# Altium schematic sheet A_Flotador.SchDoc(A_Flotador)
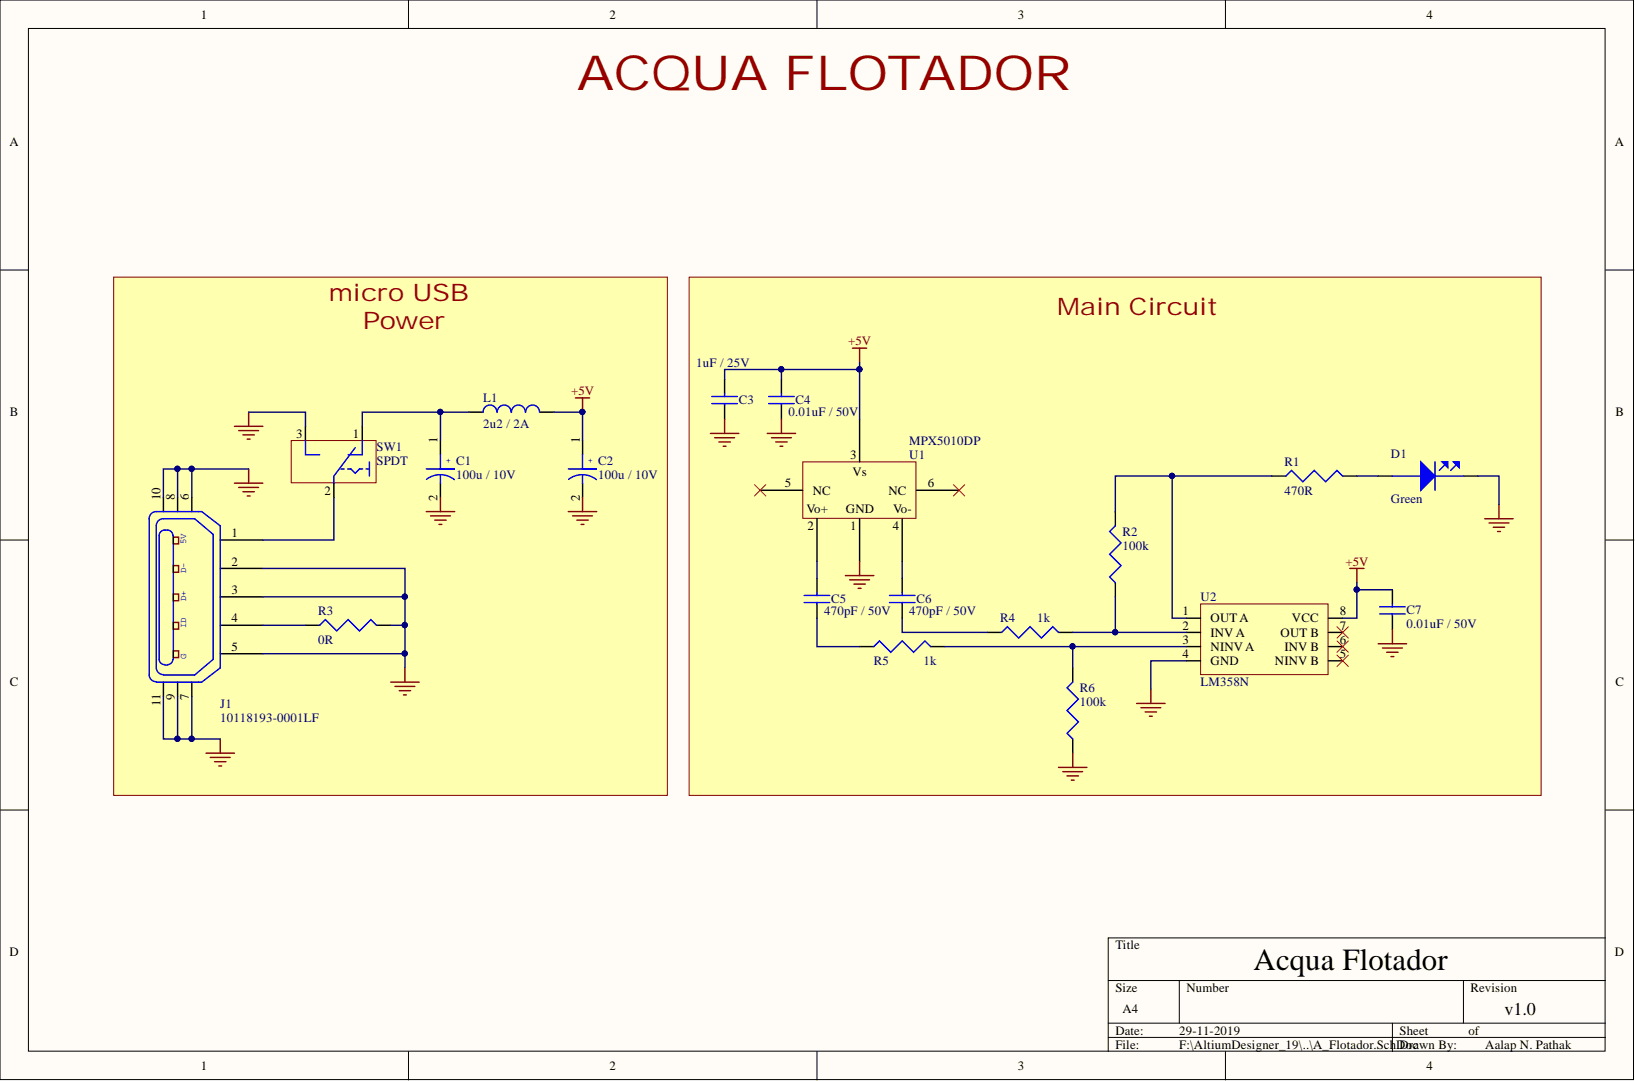
[diagram p0: A_Flotador.SchDoc]

## Parts
C1  100u / 10V  [C_ALU_C_SIZE]
C2  100u / 10V  [C_ALU_C_SIZE]
C3  1uF / 25V  Voltage Rating (DC): 25V  Capacitance: 1uF  [C0805]
C4  0.01uF / 50V  [C0805]
C5  470pF / 50V  Capacitance: 470pF  [C0805]
C6  470pF / 50V  Voltage Rating (DC): 50V  Capacitance: 470pF  [C0805]
C7  0.01uF / 50V  [C0805]
D1  Green  [LED_0603]
J1  10118193-0001LF  [MicroUSB]
L1  2u2 / 2A  Max DC Current: 2A  [C1210_IND]
R1  470R  [R0805]
R2  100k  [R0805]
R3  0R  [R0805]
R4  1k  [R0805]
R5  1k  [R0805]
R6  100k  [R0805]
SW1  SPDT  [SPDT]
U1  MPX5010DP  [MPX5010DP]
U2  LM358N  [LM358N]

## Nets
+5V: C2.1 C3.2 C4.2 C7.2 L1.2 U1.3(Vs) U2.8(VCC)
GND: C1.2 C2.2 C3.1 C4.1 C7.1 D1.1 J1.2 J1.3 J1.5 J1.6 J1.7 J1.8 J1.9 J1.10 J1.11 R3.2 R6.1 SW1.3 U1.1 U2.4(GND)
NetC1_1: C1.1 L1.1 SW1.1
NetC5_1: C5.1 R5.1
NetC5_2: C5.2 U1.2
NetC6_1: C6.1 R4.1
NetC6_2: C6.2 U1.4
NetD1_2: D1.2 R1.2
NetJ1_1: J1.1 SW1.2
NetJ1_4: J1.4 R3.1
NetR1_1: R1.1 R2.2 U2.1(OUT_A)
NetR2_1: R2.1 R4.2 U2.2(INV_A)
NetR5_2: R5.2 R6.2 U2.3(NINV_A)

Not connected: U1 5(NC) 6(NC); U2 5(NINV_B) 6(INV_B) 7(OUT_B)

## Library data of the parts
C1 C2 = EEE-1AA101WR: Cap Aluminum 100uF 10V 20% (5 X 5.4mm) SMD 50mA 1000 hr 85°C T/R; Manufacturer: Panasonic; Life (Hours): 1000Hour; Voltage Rating (DC): 10V; Voltage Rating: 10V; Tolerance: 20%; Termination: SMD/SMT; Supplier Part Number 1: PCE3867CT-ND; Supplier 1: Digi-Key; Stock 1: 121782; RoHS: Yes; Ripple Current (AC): 50mA; Ripple Current: 50mA; REACH SVHC: Unknown; Radiation Hardening: No; Pricing 1: 1 = 0.32000, 10 = 0.22100, 100 = 0.13280, 500 = 0.09964 (USD); Packaging: Tape and Reel; Package Quantity: 1; Mount: Surface Mount,PCB; Min Operating Temperature: -40°C; Max Operating Temperature: 85°C; Manufacturer Part Number: EEE-1AA101WR; Length: 5.5mm; Lead Free: Lead Free; Height - Seated (Max): 0.213inch; Height: 5.4mm; Diameter: 5mm; Contact Plating: Tin; ComponentLink8URL: https://datasheet.ciiva.com/11673/aba0000ce2-11673882.pdf?src-supplier=Avnet; ComponentLink8Description: https://datasheet.ciiva.com/11673/aba0000ce2-11673882.pdf?src-supplier=Avnet; ComponentLink7URL: https://datasheet.ciiva.com/14605/70255710-14605689.pdf?src-supplier=Allied+Electronics; ComponentLink7Description: https://datasheet.ciiva.com/14605/70255710-14605689.pdf?src-supplier=Allied+Electronics; ComponentLink6URL: https://datasheet.ciiva.com/14598/70255710-14598233.pdf?src-supplier=Allied+Electronics; ComponentLink6Description: https://datasheet.ciiva.com/14598/70255710-14598233.pdf?src-supplier=Allied+Electronics; ComponentLink5URL: https://datasheet.ciiva.com/16427/aba0000ce2-16427544.pdf?src-supplier=Future+Electronics; ComponentLink5Description: https://datasheet.ciiva.com/16427/aba0000ce2-16427544.pdf?src-supplier=Future+Electronics; ComponentLink4URL: https://datasheet.ciiva.com/30075/11aba0000ce2-30075303.pdf?src-supplier=Onlinecomponents.com; ComponentLink4Description: https://datasheet.ciiva.com/30075/11aba0000ce2-30075303.pdf?src-supplier=Onlinecomponents.com; ComponentLink3URL: https://datasheet.ciiva.com/24027/aba0000ce2-40801-24027541.pdf?src-supplier=Mouser; ComponentLink3Description: https://datasheet.ciiva.com/24027/aba0000ce2-40801-24027541.pdf?src-supplier=Mouser; ComponentLink2URL: https://datasheet.ciiva.com/2983/aba0000ce2-2983906.pdf?src-supplier=Avnet; ComponentLink2Description: https://datasheet.ciiva.com/2983/aba0000ce2-2983906.pdf?src-supplier=Avnet; ComponentLink1URL: https://datasheet.ciiva.com/26945/getdatasheetpartid-169213-26945071.pdf?src-supplier=Verical; ComponentLink1Description: https://datasheet.ciiva.com/26945/getdatasheetpartid-169213-26945071.pdf?src-supplier=Verical; Component Kind: Standard; Case/Package: Radial; Capacitance: 100uF; Library Name: AFlotador_SchLib.SchLib
C3 = C2012X5R1E105K125AA: CAP CER 1UF 25V X5R 0805; Manufacturer: TDK; Tolerance: 10%; Dielectric Material: Ceramic; Width: 0.049inch; Weight: 0.000194oz; Voltage Rating: 25V; Voltage: 25V; Thickness: 0.057inch; Termination: SMD/SMT; Supplier Part Number 1: 445-1419-1-ND; Supplier 1: Digi-Key; Stock 1: 57325; RoHS: Yes; REACH SVHC: No SVHC; Radiation Hardening: No; Pricing 1: 1 = 0.27000, 10 = 0.18200, 100 = 0.09230, 500 = 0.06314, 1000 = 0.05586 (USD); Packaging: Tape and Reel; Package Quantity: 2000; Number of Pins: 2; Mount: Surface Mount; Min Operating Temperature: -55°C; Max Operating Temperature: 85°C; Manufacturer Part Number: C2012X5R1E105K125AA; Length: 2mm; Lead Free: Lead Free; Height: 1.25mm; Dielectric: X5R; Depth: 1.25mm; Composition: Ceramic; ComponentLink4URL: https://datasheet.ciiva.com/15192/getdatasheetpartid-726707-15192140.pdf?src-supplier=Verical; ComponentLink4Description: https://datasheet.ciiva.com/15192/getdatasheetpartid-726707-15192140.pdf?src-supplier=Verical; ComponentLink3URL: https://datasheet.ciiva.com/28602/1744655-28602171.pdf?src-supplier=Element14; ComponentLink3Description: https://datasheet.ciiva.com/28602/1744655-28602171.pdf?src-supplier=Element14; ComponentLink2URL: https://datasheet.ciiva.com/16449/e412-c-16449595.pdf?src-supplier=Future+Electronics; ComponentLink2Description: https://datasheet.ciiva.com/16449/e412-c-16449595.pdf?src-supplier=Future+Electronics; ComponentLink1URL: https://datasheet.ciiva.com/11787/0900766b80c1bb00-11787608.pdf?src-supplier=RSComponents; ComponentLink1Description: https://datasheet.ciiva.com/11787/0900766b80c1bb00-11787608.pdf?src-supplier=RSComponents; Component Kind: Standard; Case Code (Metric): 2012; Case Code (Imperial): 0805; Case/Package: 0805; Library Name: AFlotador_SchLib.SchLib
C4 C7 = C0805C103K5RACTU: CAP CER 0.01UF 50V X7R 0805; Manufacturer: KEMET; Tolerance: 10%; Capacitance: 10nF; Width: 1.2mm; Voltage Rating (DC): 50V; Voltage Rating: 50V; Voltage: 50V; Termination: SMD/SMT; Supplier Part Number 1: 399-1158-1-ND; Supplier 1: Digi-Key; Stock 1: 3202760; RoHS: Yes; Radiation Hardening: No; Pricing 1: 1 = 0.15000, 10 = 0.10500, 100 = 0.04950, 500 = 0.03474, 1000 = 0.02876 (USD); Packaging: Tape and Reel; Package Quantity: 4000; Mount: Surface Mount; Min Operating Temperature: -55°C; Max Operating Temperature: 125°C; Manufacturer Part Number: C0805C103K5RACTU; Length: 2mm; Lead Pitch: 0.75mm; Height: 0.78mm; Dielectric: X7R; Depth: 1.25mm; Contact Plating: Tin,Matte; ComponentLink7URL: https://api.kemet.com/component-edge/download/datasheet/C0805C103K5RACTU.pdf?src-supplier=Digi-Key; ComponentLink7Description: https://api.kemet.com/component-edge/download/datasheet/C0805C103K5RACTU.pdf?src-supplier=Digi-Key; ComponentLink6URL: http://www.farnell.com/datasheets/2121238.pdf?src-supplier=Element14; ComponentLink6Description: http://www.farnell.com/datasheets/2121238.pdf?src-supplier=Element14; ComponentLink5URL: http://www.kemet.com/Lists/ProductCatalog/Attachments/53/KEM_C1002_X7R_SMD.pdf?src-supplier=Avnet; ComponentLink5Description: http://www.kemet.com/Lists/ProductCatalog/Attachments/53/KEM_C1002_X7R_SMD.pdf?src-supplier=Avnet; ComponentLink4URL: http://www.farnell.com/datasheets/2315425.pdf?src-supplier=Farnell; ComponentLink4Description: http://www.farnell.com/datasheets/2315425.pdf?src-supplier=Farnell; ComponentLink3URL: https://www.verical.com/server-webapp/html/getDatasheet?partID=293414&src-supplier=Verical; ComponentLink3Description: https://www.verical.com/server-webapp/html/getDatasheet?partID=293414&src-supplier=Verical; ComponentLink2URL: http://components.arrow.com/part/dataview/0323_00011329?src-supplier=Arrow+Europe; ComponentLink2Description: http://components.arrow.com/part/dataview/0323_00011329?src-supplier=Arrow+Europe; ComponentLink1URL: http://www.onlinecomponents.com/productFiles/mf-KEM/kem_c1002_x7r_smd.pdf?src-supplier=Onlinecomponents.com; ComponentLink1Description: http://www.onlinecomponents.com/productFiles/mf-KEM/kem_c1002_x7r_smd.pdf?src-supplier=Onlinecomponents.com; Component Kind: Standard; Case Code (Metric): 2012; Case Code (Imperial): 0805; Case/Package: 0805; Library Name: AFlotador_SchLib.SchLib
C5 C6 = C0805C471K5RACTU: CAP CER 470PF 50V X7R 0805; Manufacturer: KEMET; Tolerance: 10%; Dissipation Factor: 2.5%; Dielectric Material: Ceramic; Width: 1.2mm; Voltage Rating: 50V; Voltage: 50V; Thickness: 0.035inch; Termination: SMD/SMT; Supplier Part Number 1: 399-1142-1-ND; Supplier 1: Digi-Key; Stock 1: 229830; RoHS: Yes; REACH SVHC: No SVHC; Radiation Hardening: No; Pricing 1: 1 = 0.12000, 10 = 0.09000, 100 = 0.04030, 500 = 0.02876, 1000 = 0.02260 (USD); Packaging: Tape and Reel; Package Quantity: 1; Number of Pins: 2; Mount: Surface Mount; Min Operating Temperature: -55°C; Max Operating Temperature: 125°C; Manufacturer Part Number: C0805C471K5RACTU; Length: 2mm; Lead Pitch: 0.75mm; Height: 0.78mm; Dielectric: X7R; Depth: 1.25mm; ComponentLink4URL: https://datasheet.ciiva.com/28614/kem-c1002-x7r-smd-28614760.pdf?src-supplier=Element14; ComponentLink4Description: https://datasheet.ciiva.com/28614/kem-c1002-x7r-smd-28614760.pdf?src-supplier=Element14; ComponentLink3URL: https://datasheet.ciiva.com/46446/1851736-46446251.pdf?src-supplier=Element14; ComponentLink3Description: https://datasheet.ciiva.com/46446/1851736-46446251.pdf?src-supplier=Element14; ComponentLink2URL: https://datasheet.ciiva.com/18193/getdatasheetpartid-344591-18193834.pdf?src-supplier=Verical; ComponentLink2Description: https://datasheet.ciiva.com/18193/getdatasheetpartid-344591-18193834.pdf?src-supplier=Verical; ComponentLink1URL: https://datasheet.ciiva.com/16624/datasheetpn-c0805c471k5ractu-16624899.pdf?src-supplier=Avnet+Europe; ComponentLink1Description: https://datasheet.ciiva.com/16624/datasheetpn-c0805c471k5ractu-16624899.pdf?src-supplier=Avnet+Europe; Component Kind: Standard; Case Code (Metric): 2012; Case Code (Imperial): 0805; Case/Package: 0805; Library Name: AFlotador_SchLib.SchLib
  C5: Voltage Rating (DC): 50V
D1 = LGL29K-G2J1-24-Z: LED Uni-Color Green 572nm 2-Pin Chip 0603(1608Metric) T/R; Manufacturer: Osram Opto; Viewing Angle: 160°; Test Current: 2mA; Reverse Voltage: 12V; Number of LEDs: 1; Luminous Intensity: 5.6mcd; Luminous Flux: 0.012lm; Lens Transparency: Diffused; Lens Style: Flat,Diffused,Colorless,Rectangular; Lens Size: 0.8mm; Lens Color: White; Forward Voltage: 2.2V; Forward Current: 20mA; Dominant Wavelength: 570nm; Width: 0.8mm; Supplier Part Number 1: 475-2709-1-ND; Supplier 1: Digi-Key; Stock 1: 129040; RoHS: Yes; Radiation Hardening: No; Pricing 1: 1 = 0.50000, 10 = 0.36300, 100 = 0.23730, 500 = 0.17054, 1000 = 0.13347, 2500 = 0.12235 (USD); Packaging: Tape and Reel; Package Quantity: 5000; Number of Pins: 2; Number of Elements: 1; Mount: Surface Mount; Min Operating Temperature: -40°C; Max Operating Temperature: 100°C; Manufacturer Part Number: LGL29K-G2J1-24-Z; Length: 1.3mm; Illumination Color: Green; Height: 0.65mm; Depth: 0.8mm; ComponentLink5URL: https://datasheet.ciiva.com/2112/00077107-0-2112886.pdf?src-supplier=Digi-Key; ComponentLink5Description: https://datasheet.ciiva.com/2112/00077107-0-2112886.pdf?src-supplier=Digi-Key; ComponentLink4URL: https://datasheet.ciiva.com/2112/00077107-0-2112888.pdf?src-supplier=Digi-Key; ComponentLink4Description: https://datasheet.ciiva.com/2112/00077107-0-2112888.pdf?src-supplier=Digi-Key; ComponentLink3URL: https://datasheet.ciiva.com/2112/00077107-0-2112887.pdf?src-supplier=Digi-Key; ComponentLink3Description: https://datasheet.ciiva.com/2112/00077107-0-2112887.pdf?src-supplier=Digi-Key; ComponentLink2URL: https://datasheet.ciiva.com/15198/getdatasheetpartid-571127-15198411.pdf?src-supplier=Verical; ComponentLink2Description: https://datasheet.ciiva.com/15198/getdatasheetpartid-571127-15198411.pdf?src-supplier=Verical; ComponentLink1URL: https://datasheet.ciiva.com/4689/g-l29k-39844-4689584.pdf?src-supplier=Mouser; ComponentLink1Description: https://datasheet.ciiva.com/4689/g-l29k-39844-4689584.pdf?src-supplier=Mouser; Component Kind: Standard; Color: Green; Case Code (Metric): 1608; Case Code (Imperial): 0603; Case/Package: 0603; Library Name: AFlotador_SchLib.SchLib
J1 = 10118193-0001LF: CONN RCPT USB2.0 MICRO B SMD R/A; Manufacturer: Amphenol FCI; Voltage Rating: 100V; Pitch: 0.65mm; Number of Ports: 1; Number of Contacts: 5; Insulation Resistance: 1GR; Gender: Female; Current Rating: 1.8A; Connector Type: USB,Receptacle; Termination: Solder; Supplier Part Number 1: 609-4616-1-ND; Supplier 1: Digi-Key; Stock 1: 150983; RoHS: Yes; Radiation Hardening: No; Pricing 1: 1 = 0.43000, 10 = 0.40500, 25 = 0.36440, 50 = 0.32400, 100 = 0.31040, 250 = 0.28344, 500 = 0.26994 (USD); Packaging: Tape and Reel; Package Quantity: 1200; Orientation: Right Angle; Number of Terminals: 5; Mount: Surface Mount,Horizontal; Min Operating Temperature: -55°C; Max Voltage Rating (AC): 100V; Max Operating Temperature: 85°C; Manufacturer Part Number: 10118193-0001LF; Length: 7.86mm; Housing Material: Polymer; Flammability Rating: UL94 V-0; Features: Shielded; Depth: 5.67mm; Contact Plating: Gold; Contact Material: Copper Alloy; ComponentLink7URL: https://datasheet.ciiva.com/14618/70236827-14618887.pdf?src-supplier=Allied+Electronics; ComponentLink7Description: https://datasheet.ciiva.com/14618/70236827-14618887.pdf?src-supplier=Allied+Electronics; ComponentLink6URL: https://datasheet.ciiva.com/851/10118193-851108.pdf?src-supplier=Digi-Key; ComponentLink6Description: https://datasheet.ciiva.com/851/10118193-851108.pdf?src-supplier=Digi-Key; ComponentLink5URL: https://datasheet.ciiva.com/851/10118193-851107.pdf?src-supplier=Digi-Key; ComponentLink5Description: https://datasheet.ciiva.com/851/10118193-851107.pdf?src-supplier=Digi-Key; ComponentLink4URL: https://datasheet.ciiva.com/4598/10118193ac-197151-4598799.pdf?src-supplier=Mouser; ComponentLink4Description: https://datasheet.ciiva.com/4598/10118193ac-197151-4598799.pdf?src-supplier=Mouser; ComponentLink3URL: https://datasheet.ciiva.com/26853/getdatasheetpartid-418618-26853893.pdf?src-supplier=Verical; ComponentLink3Description: https://datasheet.ciiva.com/26853/getdatasheetpartid-418618-26853893.pdf?src-supplier=Verical; ComponentLink2URL: https://datasheet.ciiva.com/14802/10118193-0001lf-webpage-14802386.pdf?src-supplier=Arrow; ComponentLink2Description: https://datasheet.ciiva.com/14802/10118193-0001lf-webpage-14802386.pdf?src-supplier=Arrow; ComponentLink1URL: https://datasheet.ciiva.com/851/10118193-851106.pdf?src-supplier=Digi-Key; ComponentLink1Description: https://datasheet.ciiva.com/851/10118193-851106.pdf?src-supplier=Digi-Key; Component Kind: Standard; Color: Black; Library Name: AFlotador_SchLib.SchLib
L1 = L1210R2R2MDWIT: FIXED IND 2.2UH 2A 80 MOHM SMD; Manufacturer: KEMET; Tolerance: 20%; Test Frequency: 100kHz; Shielding: Unshielded; Self Resonant Frequency: 190MHz; DC Resistance (DCR): 80mR; DC Current: 1.13A; Core Material: Ferrite; Width: 0.098inch; Termination: SMD/SMT; Supplier Part Number 1: 399-9598-1-ND; Supplier 1: Digi-Key; Stock 1: 14739; RoHS: Yes; REACH SVHC: No SVHC; Radiation Hardening: No; Pricing 1: 1 = 0.55000, 10 = 0.47900, 100 = 0.34210, 500 = 0.28738 (USD); Packaging: Tape and Reel; Package Quantity: 1000; Mount: Surface Mount; Min Operating Temperature: -40°C; Military Standard: Not; Max Operating Temperature: 105°C; Manufacturer Part Number: L1210R2R2MDWIT; Length: 0.126inch; Inductance Tolerance: 20%; Inductance: 2.2uH; Height - Seated (Max): 0.106inch; Height: 2.5mm; Depth: 2.7mm; Current Rating: 2A; Composition: Wirewound,Ceramic; ComponentLink5URL: https://datasheet.ciiva.com/8512/f3115-8512543.pdf?src-supplier=Avnet; ComponentLink5Description: https://datasheet.ciiva.com/8512/f3115-8512543.pdf?src-supplier=Avnet; ComponentLink4URL: https://datasheet.ciiva.com/6691/f3115-6691487.pdf?src-supplier=Digi-Key; ComponentLink4Description: https://datasheet.ciiva.com/6691/f3115-6691487.pdf?src-supplier=Digi-Key; ComponentLink3URL: https://datasheet.ciiva.com/4957/f3115-4957838.pdf?src-supplier=Mouser; ComponentLink3Description: https://datasheet.ciiva.com/4957/f3115-4957838.pdf?src-supplier=Mouser; ComponentLink2URL: https://datasheet.ciiva.com/22685/27262-22685038.pdf?src-supplier=Element14; ComponentLink2Description: https://datasheet.ciiva.com/22685/27262-22685038.pdf?src-supplier=Element14; ComponentLink1URL: https://datasheet.ciiva.com/17280/f3115-17280270.pdf?src-supplier=Avnet+Europe; ComponentLink1Description: https://datasheet.ciiva.com/17280/f3115-17280270.pdf?src-supplier=Avnet+Europe; Component Kind: Standard; Case Code (Metric): 3225; Case Code (Imperial): 1210; Case/Package: 1210; Library Name: AFlotador_SchLib.SchLib
R1 = RC0805FR-07470RL: RES SMD 470 OHM 1% 1/8W 0805; Manufacturer: Yageo; Voltage Rating (DC): 150V; Temperature Coefficient: 100ppm/°C; Power Rating: 125mW; Width: 1.25mm; Voltage Rating: 150V; Tolerance: 1%; Termination: SMD/SMT; Supplier Part Number 1: 311-470CRCT-ND; Supplier 1: Digi-Key; Stock 1: 1101328; RoHS: Yes; Resistance: 470R; REACH SVHC: Unknown; Pricing 1: 1 = 0.10000, 10 = 0.04000, 100 = 0.01630, 1000 = 0.00731, 2500 = 0.00635 (USD); Packaging: Tape and Reel; Package Quantity: 1; Number of Terminations: 2; Number of Pins: 2; Min Operating Temperature: -55°C; Military Standard: Not; Max Power Dissipation: 125mW; Max Operating Temperature: 155°C; Manufacturer Part Number: RC0805FR-07470RL; Length: 2mm; Lead Pitch: 0.4mm; Lead Free: Lead Free; Height: 0.5mm; Features: Moisture Resistant; Depth: 1.25mm; Composition: Thick Film; ComponentLink7URL: https://datasheet.ciiva.com/16745/rc0805-16745229.pdf?src-supplier=Future+Electronics; ComponentLink7Description: https://datasheet.ciiva.com/16745/rc0805-16745229.pdf?src-supplier=Future+Electronics; ComponentLink6URL: https://datasheet.ciiva.com/46447/444164-46447580.pdf?src-supplier=Element14; ComponentLink6Description: https://datasheet.ciiva.com/46447/444164-46447580.pdf?src-supplier=Element14; ComponentLink5URL: https://datasheet.ciiva.com/7941/444164-7941798.pdf?src-supplier=Element14; ComponentLink5Description: https://datasheet.ciiva.com/7941/444164-7941798.pdf?src-supplier=Element14; ComponentLink4URL: https://datasheet.ciiva.com/7941/444164-7941797.pdf?src-supplier=Element14; ComponentLink4Description: https://datasheet.ciiva.com/7941/444164-7941797.pdf?src-supplier=Element14; ComponentLink3URL: https://datasheet.ciiva.com/2457/pyu-r-int-thick-7-2457058.pdf?src-supplier=Digi-Key; ComponentLink3Description: https://datasheet.ciiva.com/2457/pyu-r-int-thick-7-2457058.pdf?src-supplier=Digi-Key; ComponentLink2URL: https://datasheet.ciiva.com/2457/pyu-r-int-thick-7-2457057.pdf?src-supplier=Digi-Key; ComponentLink2Description: https://datasheet.ciiva.com/2457/pyu-r-int-thick-7-2457057.pdf?src-supplier=Digi-Key; ComponentLink1URL: https://datasheet.ciiva.com/2457/pyu-r-int-thick-7-2457056.pdf?src-supplier=Digi-Key; ComponentLink1Description: https://datasheet.ciiva.com/2457/pyu-r-int-thick-7-2457056.pdf?src-supplier=Digi-Key; Component Kind: Standard; Case Code (Metric): 2012; Case Code (Imperial): 0805; Case/Package: 0805; Library Name: AFlotador_SchLib.SchLib
R2 R6 = RC0805FR-07100KL: RES SMD 100K OHM 1% 1/8W 0805; Manufacturer: Yageo; Voltage Rating (DC): 150V; Temperature Coefficient: 100ppm/°C; Resistance: 100kR; Power Rating: 125mW; Width: 0.049inch; Voltage Rating: 150V; Tolerance: 1%; Termination: SMD/SMT; Supplier Part Number 1: 311-100KCRCT-ND; Supplier 1: Digi-Key; Stock 1: 7766985; RoHS: Yes; REACH SVHC: Unknown; Pricing 1: 1 = 0.10000, 10 = 0.03800, 100 = 0.01550, 1000 = 0.00694, 2500 = 0.00603 (USD); Packaging: Tape and Reel; Package Quantity: 1; Number of Terminations: 2; Number of Pins: 2; Min Operating Temperature: -55°C; Military Standard: Not; Max Power Dissipation: 125mW; Max Operating Temperature: 155°C; Manufacturer Part Number: RC0805FR-07100KL; Length: 2mm; Lead Pitch: 0.4mm; Lead Free: Lead Free; Height: 0.024inch; Features: Moisture Resistant; Depth: 1.25mm; Composition: Thick Film; ComponentLink11URL: https://datasheet.ciiva.com/22747/444164-22747534.pdf?src-supplier=Element14; ComponentLink11Description: https://datasheet.ciiva.com/22747/444164-22747534.pdf?src-supplier=Element14; ComponentLink10URL: https://datasheet.ciiva.com/7941/1697719-7941678.pdf?src-supplier=Element14; ComponentLink10Description: https://datasheet.ciiva.com/7941/1697719-7941678.pdf?src-supplier=Element14; ComponentLink9URL: https://datasheet.ciiva.com/7941/1686128-7941677.pdf?src-supplier=Element14; ComponentLink9Description: https://datasheet.ciiva.com/7941/1686128-7941677.pdf?src-supplier=Element14; ComponentLink8URL: https://datasheet.ciiva.com/7941/1697719-7941676.pdf?src-supplier=Element14; ComponentLink8Description: https://datasheet.ciiva.com/7941/1697719-7941676.pdf?src-supplier=Element14; ComponentLink7URL: https://datasheet.ciiva.com/7660/38627-7660796.pdf?src-supplier=Element14; ComponentLink7Description: https://datasheet.ciiva.com/7660/38627-7660796.pdf?src-supplier=Element14; ComponentLink6URL: https://datasheet.ciiva.com/2457/pyu-r-int-thick-7-2457019.pdf?src-supplier=Digi-Key; ComponentLink6Description: https://datasheet.ciiva.com/2457/pyu-r-int-thick-7-2457019.pdf?src-supplier=Digi-Key; ComponentLink5URL: https://datasheet.ciiva.com/16747/rc0805-16747226.pdf?src-supplier=Future+Electronics; ComponentLink5Description: https://datasheet.ciiva.com/16747/rc0805-16747226.pdf?src-supplier=Future+Electronics; ComponentLink4URL: https://datasheet.ciiva.com/2457/pyu-r-int-thick-7-2457018.pdf?src-supplier=Digi-Key; ComponentLink4Description: https://datasheet.ciiva.com/2457/pyu-r-int-thick-7-2457018.pdf?src-supplier=Digi-Key; ComponentLink3URL: https://datasheet.ciiva.com/12667/rc0805-12667001.pdf?src-supplier=Mouser; ComponentLink3Description: https://datasheet.ciiva.com/12667/rc0805-12667001.pdf?src-supplier=Mouser; ComponentLink2URL: https://datasheet.ciiva.com/2457/pyu-r-int-thick-7-2457017.pdf?src-supplier=Digi-Key; ComponentLink2Description: https://datasheet.ciiva.com/2457/pyu-r-int-thick-7-2457017.pdf?src-supplier=Digi-Key; ComponentLink1URL: https://datasheet.ciiva.com/11808/0900766b8106beab-11808858.pdf?src-supplier=RSComponents; ComponentLink1Description: https://datasheet.ciiva.com/11808/0900766b8106beab-11808858.pdf?src-supplier=RSComponents; Component Kind: Standard; Case Code (Metric): 2012; Case Code (Imperial): 0805; Case/Package: 0805; Library Name: AFlotador_SchLib.SchLib
R3 = RC0805JR-070RL: RES SMD 0 OHM JUMPER 1/8W 0805; Manufacturer: Yageo; Voltage Rating (DC): 150V; Tolerance: 5%; Temperature Coefficient: 200ppm/°C; Resistance: 0mR; Power Rating: 125mW; Width: 1.25mm; Voltage Rating: 150V; Termination: SMD/SMT; Supplier Part Number 1: 311-0.0ARCT-ND; Supplier 1: Digi-Key; Stock 1: 13963017; RoHS: Yes; REACH SVHC: Unknown; Radiation Hardening: No; Pricing 1: 1 = 0.10000, 10 = 0.03800, 25 = 0.02760, 50 = 0.02100, 100 = 0.01540, 250 = 0.01180, 500 = 0.00942, 1000 = 0.00694 (USD); Packaging: Tape and Reel; Number of Pins: 2; Min Operating Temperature: -55°C; Military Standard: Not; Max Operating Temperature: 155°C; Manufacturer Part Number: RC0805JR-070RL; Length: 2mm; Lead Free: Lead Free; Height: 0.5mm; Depth: 1.25mm; Contact Plating: Tin,Matte; Composition: Thick Film; ComponentLink5URL: https://datasheet.ciiva.com/15190/getdatasheetpartid-330685-15190028.pdf?src-supplier=Verical; ComponentLink5Description: https://datasheet.ciiva.com/15190/getdatasheetpartid-330685-15190028.pdf?src-supplier=Verical; ComponentLink4URL: https://datasheet.ciiva.com/7941/1738694-7941863.pdf?src-supplier=Element14; ComponentLink4Description: https://datasheet.ciiva.com/7941/1738694-7941863.pdf?src-supplier=Element14; ComponentLink3URL: https://datasheet.ciiva.com/7941/1738694-7941862.pdf?src-supplier=Element14; ComponentLink3Description: https://datasheet.ciiva.com/7941/1738694-7941862.pdf?src-supplier=Element14; ComponentLink2URL: https://datasheet.ciiva.com/11819/0900766b8106beab-11819430.pdf?src-supplier=RSComponents; ComponentLink2Description: https://datasheet.ciiva.com/11819/0900766b8106beab-11819430.pdf?src-supplier=RSComponents; ComponentLink1URL: https://datasheet.ciiva.com/7941/1738694-7941861.pdf?src-supplier=Element14; ComponentLink1Description: https://datasheet.ciiva.com/7941/1738694-7941861.pdf?src-supplier=Element14; Component Kind: Standard; Case Code (Metric): 2012; Case Code (Imperial): 0805; Case/Package: 0805; Library Name: AFlotador_SchLib.SchLib
R4 R5 = RC0805FR-071KL: RES SMD 1K OHM 1% 1/8W 0805; Manufacturer: Yageo Phycomp; Voltage Rating (DC): 150V; Temperature Coefficient: 100ppm/°C; Resistance: 1000R; Power Rating: 125mW; Width: 1.25mm; Tolerance: 1%; Supplier Part Number 1: 311-1.00KCRCT-ND; Supplier 1: Digi-Key; Stock 1: 26091057; RoHS: Yes; REACH SVHC: No SVHC; Pricing 1: 1 = 0.10000, 10 = 0.03700, 100 = 0.01490, 1000 = 0.00668, 2500 = 0.00580 (USD); Packaging: Cut Tape; Number of Pins: 2; Mount: Surface Mount; Min Operating Temperature: -55°C; Max Operating Temperature: 155°C; Manufacturer Part Number: RC0805FR-071KL; Length: 2mm; Lead Free: Lead Free; Height: 0.5mm; Depth: 1.25mm; ComponentLink5URL: http://www.farnell.com/datasheets/1697719.pdf?src-supplier=Element14; ComponentLink5Description: http://www.farnell.com/datasheets/1697719.pdf?src-supplier=Element14; ComponentLink4URL: http://www.farnell.com/datasheets/2167052.pdf?src-supplier=Newark; ComponentLink4Description: http://www.farnell.com/datasheets/2167052.pdf?src-supplier=Newark; ComponentLink3URL: http://www.yageo.com/documents/recent/PYu-RC_Group_51_RoHS_L_10.pdf?src-supplier=Digi-Key; ComponentLink3Description: http://www.yageo.com/documents/recent/PYu-RC_Group_51_RoHS_L_10.pdf?src-supplier=Digi-Key; ComponentLink2URL: http://www.mouser.com/ds/2/447/Yageo_08112016_RC0805-968729.pdf?src-supplier=Mouser; ComponentLink2Description: http://www.mouser.com/ds/2/447/Yageo_08112016_RC0805-968729.pdf?src-supplier=Mouser; ComponentLink1URL: http://www.farnell.com/datasheets/2167052.pdf?src-supplier=Farnell; ComponentLink1Description: http://www.farnell.com/datasheets/2167052.pdf?src-supplier=Farnell; Component Kind: Standard; Case/Package: 0805; Library Name: AFlotador_SchLib.SchLib
SW1 = OS102011MS2QN1: C & K COMPONENTS         OS102011MS2QN1             Slide Switch, SPDT, On-On, Through Hole, OS Series, 100 mA; Manufacturer: ITT C&K; Voltage Rating (DC): 12V; Switch Travel: 2mm; Mechanical Life: 10000Cycles; Max Current Rating: 100mA; Insulation Resistance: 100MR; Contact Resistance: 20mR; Actuator Type: Standard; Actuator Material: Polyoxymethylene,Acetal,Polyacetal; Actuator Length: 4mm; Throw Configuration: SPDT; Termination: PC Pins; Switch Function: On-On; Supplier Part Number 1: CKN9565-ND; Supplier 1: Digi-Key; Stock 1: 21108; RoHS: Yes; REACH SVHC: No SVHC; Radiation Hardening: No; Pricing 1: 1 = 0.43000, 10 = 0.41300, 25 = 0.40480, 50 = 0.36160, 100 = 0.34440, 250 = 0.33580, 500 = 0.28414, 1000 = 0.27552, 5000 = 0.24108 (USD); Packaging: Bulk; Package Quantity: 300; Orientation: Vertical; Mount: Through Hole,PCB; Min Operating Temperature: -30°C; Max Voltage Rating (DC): 12V; Max Operating Temperature: 70°C; Manufacturer Part Number: OS102011MS2QN1; Length: 8.6mm; Lead Free: Lead Free; Housing Material: Resin; Electrical Life: 10000Cycles; Depth: 4.3mm; Current Rating: 100mA; Contact Plating: Silver,Gold; Contact Material: Copper Alloy,Brass,Silver; Contact Current Rating: 100mA; ComponentLink8URL: https://datasheet.ciiva.com/26290/os-11mar13-26290652.pdf?src-supplier=Onlinecomponents.com; ComponentLink8Description: https://datasheet.ciiva.com/26290/os-11mar13-26290652.pdf?src-supplier=Onlinecomponents.com; ComponentLink7URL: https://datasheet.ciiva.com/16997/70128245-16997771.pdf?src-supplier=Allied+Electronics; ComponentLink7Description: https://datasheet.ciiva.com/16997/70128245-16997771.pdf?src-supplier=Allied+Electronics; ComponentLink6URL: https://datasheet.ciiva.com/18147/getdatasheetpartid-320490-18147210.pdf?src-supplier=Verical; ComponentLink6Description: https://datasheet.ciiva.com/18147/getdatasheetpartid-320490-18147210.pdf?src-supplier=Verical; ComponentLink5URL: https://datasheet.ciiva.com/1699/os-19jan15-1699479.pdf?src-supplier=Newark; ComponentLink5Description: https://datasheet.ciiva.com/1699/os-19jan15-1699479.pdf?src-supplier=Newark; ComponentLink4URL: https://datasheet.ciiva.com/2889/os-19jan15-2889173.pdf?src-supplier=Digi-Key; ComponentLink4Description: https://datasheet.ciiva.com/2889/os-19jan15-2889173.pdf?src-supplier=Digi-Key; ComponentLink3URL: https://datasheet.ciiva.com/1532/1683358-1532308.pdf?src-supplier=Element14; ComponentLink3Description: https://datasheet.ciiva.com/1532/1683358-1532308.pdf?src-supplier=Element14; ComponentLink2URL: https://datasheet.ciiva.com/16298/os102011ms2qn1-16298526.pdf?src-supplier=Future+Electronics; ComponentLink2Description: https://datasheet.ciiva.com/16298/os102011ms2qn1-16298526.pdf?src-supplier=Future+Electronics; ComponentLink1URL: https://datasheet.ciiva.com/30466/0900766b81263f61-30466806.pdf?src-supplier=RSComponents; ComponentLink1Description: https://datasheet.ciiva.com/30466/0900766b81263f61-30466806.pdf?src-supplier=RSComponents; Component Kind: Standard; Library Name: AFlotador_SchLib.SchLib
U1 = MPX5010DP: NXP         MPX5010DP             Pressure Sensor, Differential, 450 mV/kPa, 0 kPa, 10 kPa, 4.75 V, 5.25 V; Manufacturer: NXP Freescale; Response Time: 1ms; Port Style: Barbed; Output Voltage: 4.7V; Output Type: Analog Voltage,Analog; Operating Supply Voltage: 5V; Operating Pressure: 1.45PSI; Nominal Supply Current: 5mA; Min Supply Voltage: 4.75V; Max Supply Voltage: 5.25V; Max Pressure: 5.8PSI; Accuracy: 5%; Weight: 0.184887oz; Termination: PCB; Supplier Part Number 1: MPX5010DP-ND; Supplier 1: Digi-Key; Stock 1: 0; RoHS: Yes; REACH SVHC: No SVHC; Radiation Hardening: No; Pricing 1: 1 = 16.33000, 10 = 13.33200, 25 = 12.33200, 100 = 10.33230, 500 = 9.66570, 1000 = 9.16575 (USD); Pressure Type: Differential; Packaging: Tray; Package Quantity: 100; Number of Pins: 6; Mount: Through Hole; Min Operating Temperature: -40°C; Max Operating Temperature: 125°C; Manufacturer Part Number: MPX5010DP; Lead Free: Lead Free; Halogen Free: Not Halogen Free; ComponentLink5URL: https://datasheet.ciiva.com/1513/1738898-1513837.pdf?src-supplier=Element14; ComponentLink5Description: https://datasheet.ciiva.com/1513/1738898-1513837.pdf?src-supplier=Element14; ComponentLink4URL: https://datasheet.ciiva.com/16373/mpx5010-16373114.pdf?src-supplier=Future+Electronics; ComponentLink4Description: https://datasheet.ciiva.com/16373/mpx5010-16373114.pdf?src-supplier=Future+Electronics; ComponentLink3URL: https://datasheet.ciiva.com/2825/mpx5010-2825379.pdf?src-supplier=Digi-Key; ComponentLink3Description: https://datasheet.ciiva.com/2825/mpx5010-2825379.pdf?src-supplier=Digi-Key; ComponentLink2URL: https://datasheet.ciiva.com/26929/mpx5010dp-26929428.pdf?src-supplier=IIIC; ComponentLink2Description: https://datasheet.ciiva.com/26929/mpx5010dp-26929428.pdf?src-supplier=IIIC; ComponentLink1URL: https://datasheet.ciiva.com/14094/rkmotorola-drucksensor-en-14094760.pdf?src-supplier=Distrelec; ComponentLink1Description: https://datasheet.ciiva.com/14094/rkmotorola-drucksensor-en-14094760.pdf?src-supplier=Distrelec; Component Kind: Standard; Case/Package: SIP; Library Name: AFlotador_SchLib.SchLib
U2 = LM358N/NOPB: IC OPAMP GP 1MHZ 8DIP; Manufacturer: TI National Semiconductor; Voltage Gain: 100dB; Slew Rate: 0.1V/µs; Power Supply Rejection Ratio (PSRR): 65dB; Power Dissipation: 830mW; Output Current per Channel: 40mA; Output Current: 30mA; Operating Supply Voltage: 16V; Operating Supply Current: 1mA; Number of Elements: 2; Nominal Supply Current: 500uA; Min Supply Voltage: 3V; Min Dual Supply Voltage: 1.5V; Max Supply Voltage: 32V; Max Supply Current: 1.2mA; Input Offset Voltage (Vos): 7mV; Input Bias Current: 250nA; Width: 6.35mm; Weight: 0.01lb; Unity Gain Bandwidth Product: 1MHz; Supplier Part Number 1: LM358NNS/NOPB-ND; Supplier 1: Digi-Key; Stock 1: 3167; RoHS: Yes; REACH SVHC: No SVHC; Radiation Hardening: No; Pricing 1: 1 = 0.84000, 10 = 0.75500, 25 = 0.71680, 100 = 0.55040, 500 = 0.46080, 1000 = 0.35840, 2500 = 0.34048, 5000 = 0.32768 (USD); Packaging: Rail/Tube; Package Quantity: 40; Number of Pins: 8; Number of Circuits: 2; Number of Channels: 2; Number of Amplifiers: 2; Mount: Through Hole; Min Operating Temperature: 0°C; Max Power Dissipation: 830mW; Max Operating Temperature: 70°C; Max Dual Supply Voltage: 16V; Manufacturer Part Number: LM358N/NOPB; Length: 9.27mm; Lead Free: Lead Free; Height: 3.3mm; Gain Bandwidth Product: 1MHz; ComponentLink8URL: https://datasheet.ciiva.com/26061/lm2904-n-26061750.pdf?src-supplier=Avnet+Europe; ComponentLink8Description: https://datasheet.ciiva.com/26061/lm2904-n-26061750.pdf?src-supplier=Avnet+Europe; ComponentLink7URL: https://datasheet.ciiva.com/6625/lm158-n-6625621.pdf?src-supplier=Digi-Key; ComponentLink7Description: https://datasheet.ciiva.com/6625/lm158-n-6625621.pdf?src-supplier=Digi-Key; ComponentLink6URL: https://datasheet.ciiva.com/14151/ohlmx58-lm2904-e-14151404.pdf?src-supplier=Distrelec; ComponentLink6Description: https://datasheet.ciiva.com/14151/ohlmx58-lm2904-e-14151404.pdf?src-supplier=Distrelec; ComponentLink5URL: https://datasheet.ciiva.com/8009/28655-8009196.pdf?src-supplier=Element14; ComponentLink5Description: https://datasheet.ciiva.com/8009/28655-8009196.pdf?src-supplier=Element14; ComponentLink4URL: https://datasheet.ciiva.com/2973/lm2904-n-2973398.pdf?src-supplier=Avnet; ComponentLink4Description: https://datasheet.ciiva.com/2973/lm2904-n-2973398.pdf?src-supplier=Avnet; ComponentLink3URL: https://datasheet.ciiva.com/26850/lm358n-nopb-26850548.pdf?src-supplier=IIIC; ComponentLink3Description: https://datasheet.ciiva.com/26850/lm358n-nopb-26850548.pdf?src-supplier=IIIC; ComponentLink2URL: https://datasheet.ciiva.com/22769/1696812-22769451.pdf?src-supplier=Farnell; ComponentLink2Description: https://datasheet.ciiva.com/22769/1696812-22769451.pdf?src-supplier=Farnell; ComponentLink1URL: https://datasheet.ciiva.com/19474/839367-19474437.pdf?src-supplier=Jameco; ComponentLink1Description: https://datasheet.ciiva.com/19474/839367-19474437.pdf?src-supplier=Jameco; Component Kind: Standard; Common Mode Rejection Ratio: 65dB; Case/Package: PDIP; Bandwidth: 1MHz; Library Name: AFlotador_SchLib.SchLib
